# Altium schematic sheet main.SchDoc(main)
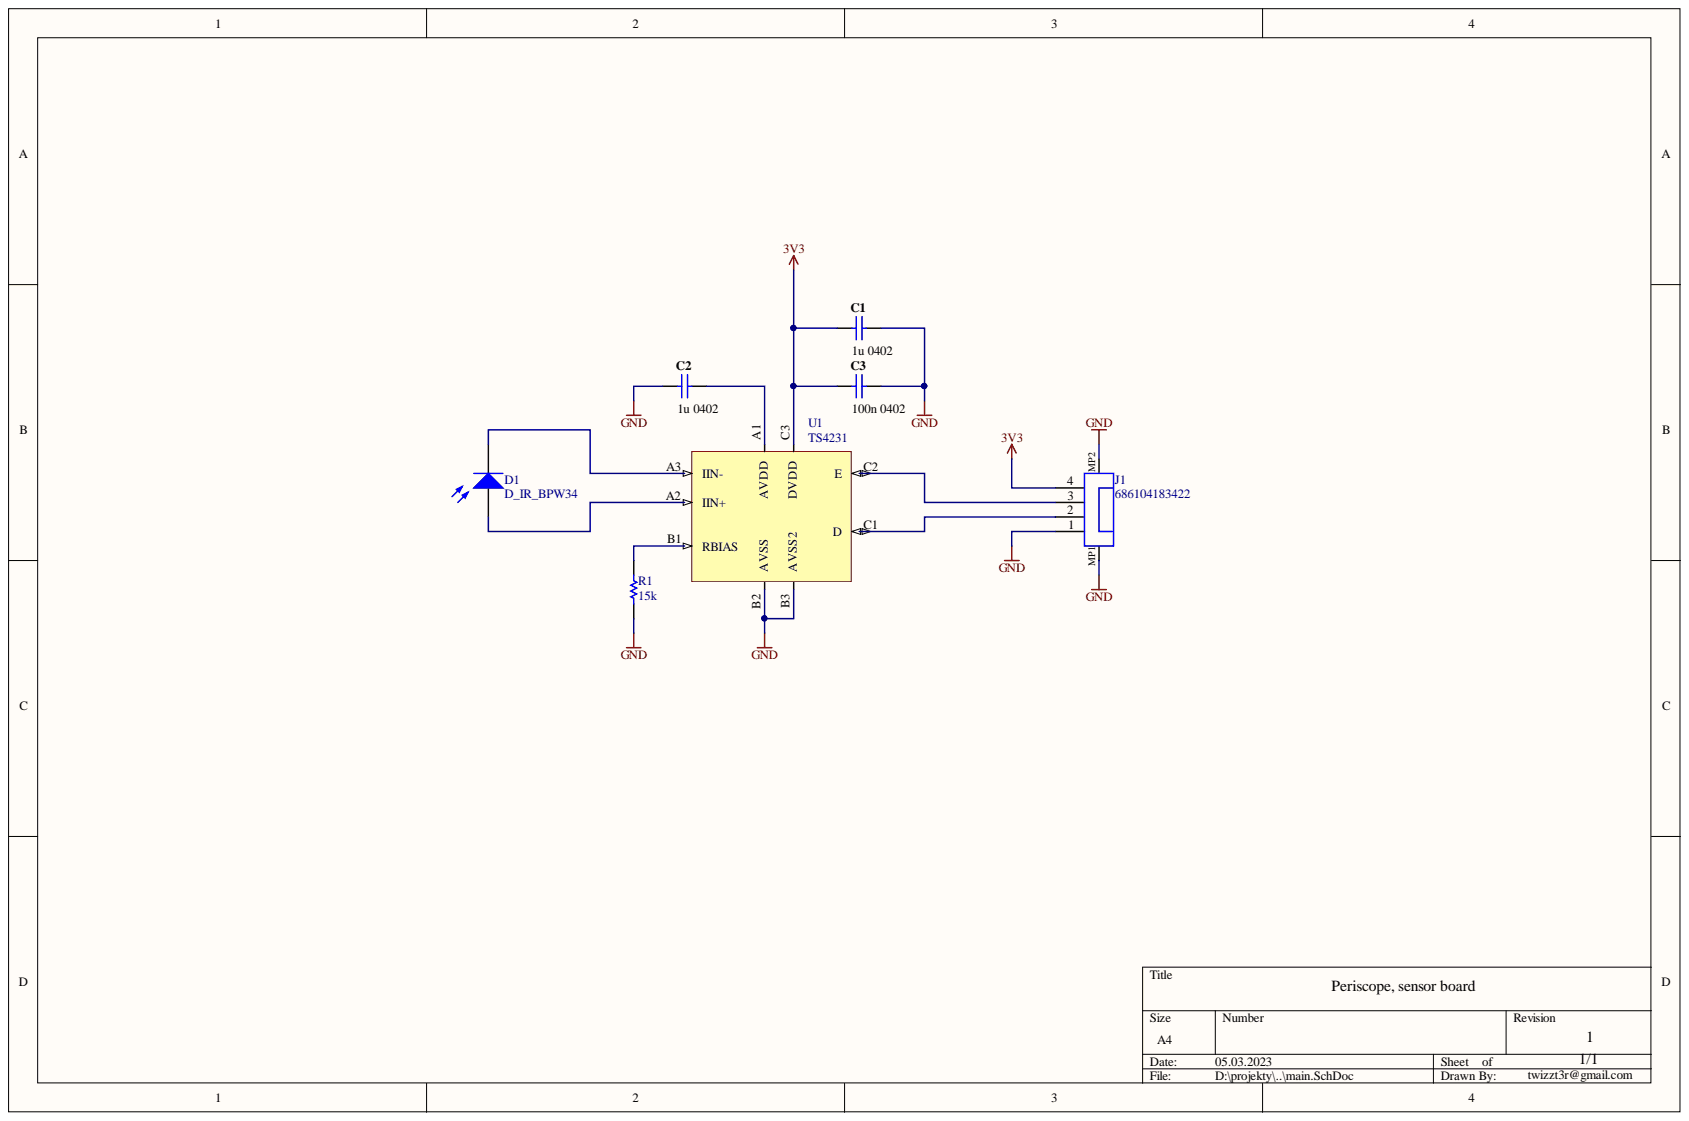
[diagram p0: main.SchDoc]

## Parts
C1  value 1u 0402  [WCAP-CSGP_0402_H=0.5mm]  (hidden comment: C_0402)
C2  value 1u 0402  [WCAP-CSGP_0402_H=0.5mm]  (hidden comment: C_0402)
C3  value 100n 0402  [WCAP-CSGP_0402_H=0.5mm]  (hidden comment: C_0402)
D1  D_IR_BPW34  [D_BPW34]
J1  686104183422  [686104183422]
R1  value 15k  [R_0603]  (hidden comment: R_0603)
U1  TS4231  [BGA9C50P3X3_162X158X62]

## Nets
3V3: C1.2 C3.2 J1.4 U1.C3(DVDD)
GND: C1.1 C2.2 C3.1 J1.1 J1.MP1 J1.MP2 R1.1 U1.B2(AVSS) U1.B3(AVSS2)
NetC2_1: C2.1 U1.A1(AVDD)
NetD1_1: D1.1 U1.A2(IIN+)
NetD1_2: D1.2 U1.A3(IIN-)
NetJ1_2: J1.2 U1.C1(D)
NetJ1_3: J1.3 U1.C2(E)
NetR1_2: R1.2 U1.B1(RBIAS)

## Library data of the parts
C1-C3 = C_0402: C; Library Name: SCH.SchLib; Component Kind: Standard
D1 = D_IR_BPW34: PIN  photodiode; mfg_pn: BPW34; Library Name: SCH.SchLib; Component Kind: Standard
J1 = 686104183422: WR-FPC SMT ZIF Horizontal Top Contact Low Profile, pitch 1mm, 4p; Manufacturer: Wurth Elektronik; Working Voltage: 50VAC; Rated Current: 0.8A; Pin Number: 4; Contact Resistance: 30mOhm; Type: Horizontal; Published Date: March 2021; Pitch: 1mm; Packaging: Tape and Reel; Operating Temperature: -25 to +85degC; Mounting Technology: SMT; Manufacturer Part Number: 686104183422; Library Name: SCH.SchLib; Length: 10.2mm; ComponentLink2URL: https://katalog.we-online.com/em/datasheet/686104183422.pdf; ComponentLink2Description: Datasheet; ComponentLink1URL: http://www.we-online.de; ComponentLink1Description: Manufacturer; Component Kind: Standard
U1 = TS4231: INFRARED RECEIVER IC FOR STEAMVR; mfg_pn: TS4231; Library Name: SCH.SchLib; Component Kind: Standard
R1 = R_0603: Library Name: SCH.SchLib; Component Kind: Standard
